# Altium schematic sheet lv_comm_i2c.SchDoc(lv_comm_i2c)
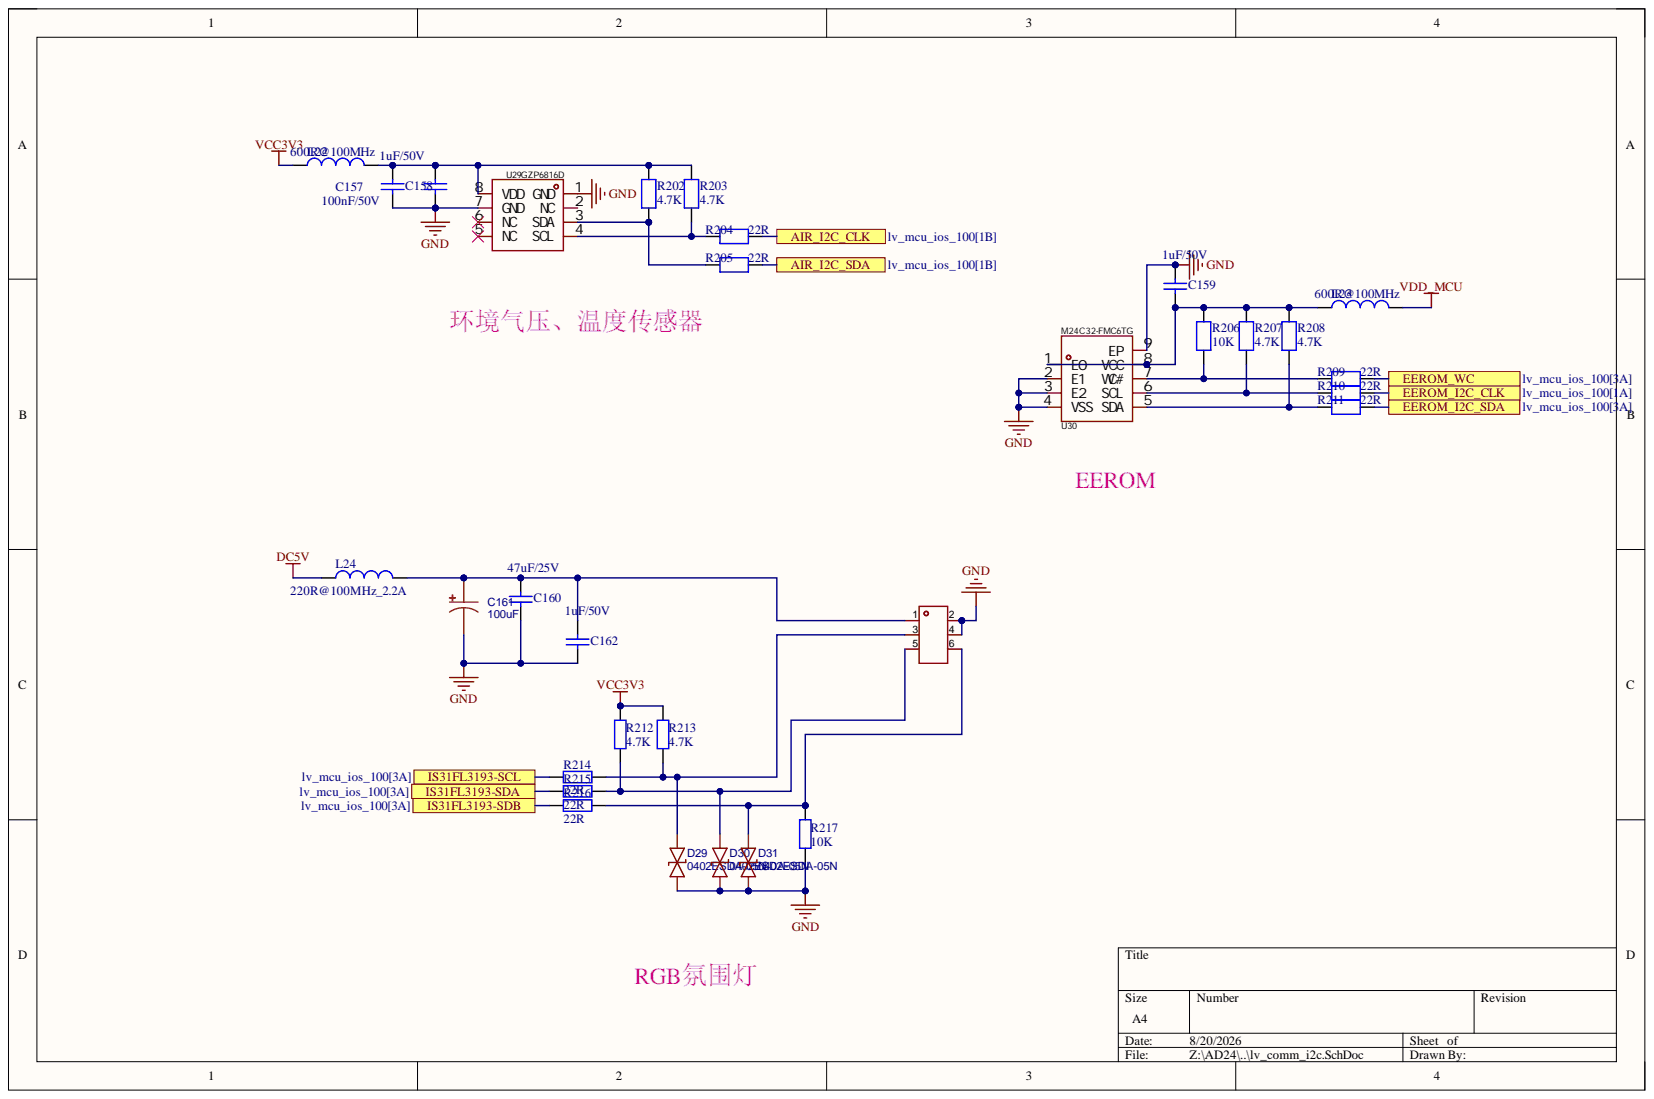
[diagram p0: lv_comm_i2c.SchDoc]

## Parts
C157  100nF/50V  [C0402]
C158  1uF/50V  [C0603]
C159  1uF/50V  [C0603]
C160  47uF/25V  [1206]
C161  100uF  [CAP-SMD_BD6.3-L6.6-W6.6-LS7.6-FD]
C162  1uF/50V  [C0603]
CN13  df11-6dp-2dsa(08)  [CONN-TH_DF11-6DP-2DSA-01]  (value not drawn)
D29  0402ESDA-05N  [D0402-BI]
D30  0402ESDA-05N  [D0402-BI]
D31  0402ESDA-05N  [D0402-BI]
L22  600R@100MHz  [L0603]
L23  600R@100MHz  [L0603]
L24  220R@100MHz_2.2A  [L0603]
R202  4.7K  [R0402]
R203  4.7K  [R0402]
R204  22R  [R0402]
R205  22R  [R0402]
R206  10K  [R0402]
R207  4.7K  value 10K  [R0402]
R208  4.7K  [R0402]
R209  22R  [R0402]
R210  22R  [R0402]
R211  22R  [R0402]
R212  4.7K  [R0402]
R213  4.7K  [R0402]
R214  22R  [R0402]
R215  22R  [R0402]
R216  22R  [R0402]
R217  10K  [R0402]
U29  GZP6816D  [SENSOR-SMD_XGZP6816D]
U30  M24C32-FMC6TG  [UFDFPN-8_L2.0-W3.0-P0.50-BL-EP]

## Ports
AIR_I2C_CLK, AIR_I2C_SDA, EEROM_I2C_CLK, EEROM_I2C_SDA, EEROM_WC, IS31FL3193-SCL, IS31FL3193-SDA, IS31FL3193-SDB

## Nets
DC5V: L24.2
GND: C157.1 C158.2 C159.1 C160.1 C161.2 C162.2 CN13.2 CN13.4 D29.1 D30.1 D31.1 R217.1 U29.1(GND) U29.7(GND) U30.2(E1) U30.3(E2) U30.4(VSS) U30.9(EP)
VCC3V3: L22.1 R212.1 R213.1
VDD_MCU: L23.2
AIR_I2C_CLK: R204.2 ; port AIR_I2C_CLK
AIR_I2C_SDA: R205.2 ; port AIR_I2C_SDA
EEROM_I2C_CLK: R210.1 ; port EEROM_I2C_CLK
EEROM_I2C_SDA: R211.1 ; port EEROM_I2C_SDA
EEROM_WC: R209.1 ; port EEROM_WC
IS31FL3193-SCL: R214.2 ; port IS31FL3193-SCL
IS31FL3193-SDA: R215.2 ; port IS31FL3193-SDA
IS31FL3193-SDB: R216.2 ; port IS31FL3193-SDB
NetC157_2: C157.2 C158.1 L22.2 R202.1 R203.1 U29.8(VDD)
NetC159_2: C159.2 L23.1 R206.2 R207.2 R208.2 U30.1(E0) U30.8(VCC)
NetC160_2: C160.2 C161.1 C162.1 CN13.1 L24.1
NetCN13_3: CN13.3 D29.2 R213.2 R214.1
NetCN13_5: CN13.5 D30.2 R212.2 R215.1
NetCN13_6: CN13.6 D31.2 R216.1 R217.2
NetR202_2: R202.2 R205.1 U29.3(SDA)
NetR203_2: R203.2 R204.1 U29.4(SCL)
NetR206_1: R206.1 R209.2 U30.7(WC#)
NetR207_1: R207.1 R210.2 U30.6(SCL)
NetR208_1: R208.1 R211.2 U30.5(SDA)

Not connected: U29 2(NC) 5(NC) 6(NC)

## Library data of the parts
C157 = Capacitor: 50V, 5%, X7R; Manufacturer: muRata; Manufacturer_Part_Number: GRM155R71H104KE14D; Component Kind: Standard; ComponentLink1Description: Datasheet; ComponentLink1URL: http://tunmcdsvn.tun.st.com/Component_Datasheet_Library/Trunk/Capacitors/Yageo_Capacitor_NP0_16V-to-50V; Library Name: HiPin_Library_AD.IntLib
C158 = MCD-00010: 50V, X5R, 10%; Manufacturer: muRata; Manufacturer_Part_Number: GRM188R71C105KE15D; Part_Type: Capacitor; Database Table Name: MCD_PARTS$; Initial_Board_Reference: MB1274; Provided_By: Subcon; Value: 1uF; Component Kind: Standard; ECCN_EU: n/a; ECCN_US: n/a; Internal_Part_Number: MCD-00010; Library Name: MCD_PARTS_database.DbLib; Package: C0603; Status: Released
C159 C162 = MCD-00010: 50V, X5R, 10%; Manufacturer: muRata; Manufacturer_Part_Number: GRM188R71C105KE15D; Database Table Name: MCD_PARTS$; Component Kind: Standard; Library Name: MCD_PARTS_database.DbLib
  C162: ComponentLink1Description: Yageo_Capacitor_X5R-series.pdf; ComponentLink1URL: http://tunmcdsvn.tun.st.com/Component_Datasheet_Library/Trunk/Capacitors/Yageo_Multilayer_capacitor_X5R_series_100pF_to_100uF
C160 = Capacitor_3: 25V, X5R, 20%; Internal_Part_Number: MCD-00008; Manufacturer: muRata; Manufacturer_Part_Number: GRM32ER61C476KE15L; Value: 47uF; Component Kind: Standard; Library Name: HiPin_Library_AD.IntLib
C161 = 100uF: 6.3mm*7.7mm; BOM_Manufacturer: Nichicon; BOM_Manufacturer Part: UWT1V101MCL1GS; Contributor: LCSC; nameAlias: Capacitance; BOM_JLCPCB Part Class: Extended Part; BOM_Supplier: LCSC; BOM_Supplier Part: C125975; Component Kind: Standard; Library Name: HiPin_Library_AD.IntLib; spicePre: C; spiceSymbolName: UWT1V101MCL1GS
CN13 = df11-6dp-2dsa: 插针结构:2x3P;间距:2mm;安装方式:直插;参考系列:-;总PIN数:6P;排数:2;每排PIN数:3;行距:2mm;额定电流:-;工作温度范围:-;; Manufacturer: HRS(广濑); AddInBom: yes; ModelId: f6522031bd444346802bb24726d5ca22; ModelTransform: 314.96,196.85,0,0,0,0,0,0,-118.11; Number of PINs Per Row: 3; Pins Structure: 2x3P; Pitch: 2mm; Component Kind: Standard; ConvertToPcb: yes; Current Rating (Max): -; Datasheet: https://atta.szlcsc.com/upload/public/pdf/source/20200402/C506668_15971A609117B16797466F71D3345786.pdf; FootprintName: CONN-TH_DF11-6DP-2DSA-01; JLCPCB Part Class: Extended Part; LCSC Part Name: 2x3P 间距:2mm 直插; Library Name: HiPin_Library_AD.IntLib; ManufacturerPart: DF11-6DP-2DSA(08); ModelName: CONN-TH_DF11-6DP-2DSA-01; Mounting Style: 直插; Number of Pins: 6P; Number of Rows: 2; Operating Temperature Range: -; Reference Series: -; Row Spacing: 2mm; Supplier: LCSC; Supplier Footprint: 插件,P=2mm; SupplierPart: C506668; Symbol: DF11-6DP-2DSA(08)
D29-D31 = 0402ESDA-05N: 双向ESD，反向截止电压5V; BOM_Manufacturer: Bourne Semicon (Shenzhen); Contributor: LCSC; BOM_JLCPCB Part Class: Extended Part; BOM_Manufacturer Part: 0402ESDA-05N; BOM_Supplier: LCSC; BOM_Supplier Part: C316049; Component Kind: Standard; Library Name: HiPin_Library_AD.IntLib; spicePre: D; spiceSymbolName: 0402ESDA-05N
L22 L23 = MCD-00047: +/-25%, 350mA, 500mohm DC; Manufacturer: TAI-TECH; Manufacturer_Part_Number: FCM1608KF-601T03; Database Table Name: MCD_PARTS$; Component Kind: Standard; ComponentLink1Description: Datasheet; ComponentLink1URL: http://tunmcdsvn.tun.st.com/Component_Datasheet_Library/Trunk/Inductors/TaiTech_Bead_FCM1608_series; Library Name: MCD_PARTS_database.DbLib
L24 = MCD-00047: SMD Chip ferrite bead, 220R@100MHz +/-25%,2.2A, 50mohm; Manufacturer: Sunlord; Manufacturer_Part_Number: UPZ1608U221-2R2TF; Database Table Name: MCD_PARTS$; Component Kind: Standard; ComponentLink1Description: Datasheet; ComponentLink1URL: http://tunmcdsvn.tun.st.com/Component_Datasheet_Library/Trunk/Inductors/TaiTech_Bead_FCM1608_series; Internal_Part_Number: MCD-00047; Library Name: MCD_PARTS_database.DbLib
R202-R205 R208-R211 = RES: 1%; Value: 10K; Component Kind: Standard; Library Name: HiPin_Library_AD.IntLib
R206 R207 = RES: 1%; Component Kind: Standard; Library Name: HiPin_Library_AD.IntLib
  R206: Value: 10K
R212-R217 = 0603 49.9KΩ (4992) 1%: 贴片电阻 1% 1/16W 100ppm; Manufacturer: YAGEO; ManufacturerNumber: RC0402FR-0710KL; Component Kind: Standard; Library Name: RES.SchLib; SuppliersPartNumber: C60490
U29 = GZP6816D: 压力传感器; Manufacturer: Sencoch(芯感智); Add into BOM: yes; Pressure range: 30Kpa~110Kpa; Standby Current: 50nA; The interface type: I2C; The Power Supply Voltage: 3.3V; Component Kind: Standard; Convert to PCB: yes; Datasheet: https://atta.szlcsc.com/upload/public/pdf/source/20220921/E22C263645ADA222538EFE98701C343D.pdf; Device: GZP6816D; JLCPCB Part Class: Extended Part; LCSC Part Name: 压力传感器GZP6816D; Library Name: HiPin_Library_AD.IntLib; Manufacturer Part: GZP6816D; Operating Temperature: -30℃~+85℃; PCB3D: SENSOR-SMD_XGZP6816D; Supplier: LCSC; Supplier Footprint: SMD-8P,2x2.5mm; Supplier Part: C3038127; Symbol: GZP6816D
U30 = M24C32-FMC6TG: EEROM; Manufacturer: ST(意法半导体); Add into BOM: yes; Interface Type: I2C; Memory Size: 32Kbit; Supply Voltage: 1.7V~5.5V; Component Kind: Standard; Convert to PCB: yes; Datasheet: https://atta.szlcsc.com/upload/public/pdf/source/20181022/C283444_BCD956C595255ABAD7DDB42E45293D41.pdf; Device: M24C32-FMC6TG; JLCPCB Part Class: Extended Part; LCSC Part Name: M24C32 FMC6TG; Library Name: HiPin_Library_AD.IntLib; Manufacturer Part: M24C32-FMC6TG; Operating Temperature: -40℃~+85℃; PCB3D: UFDFPN-8_L2.0-W3.0-H0.6-P0.50-BL-EP; Supplier: LCSC; Supplier Footprint: UFDFPN-8(2x3); Supplier Part: C2060985; Symbol: M24C32-FMC6TG
